# Altium schematic sheet AUDIO.SchDoc(AUDIO)
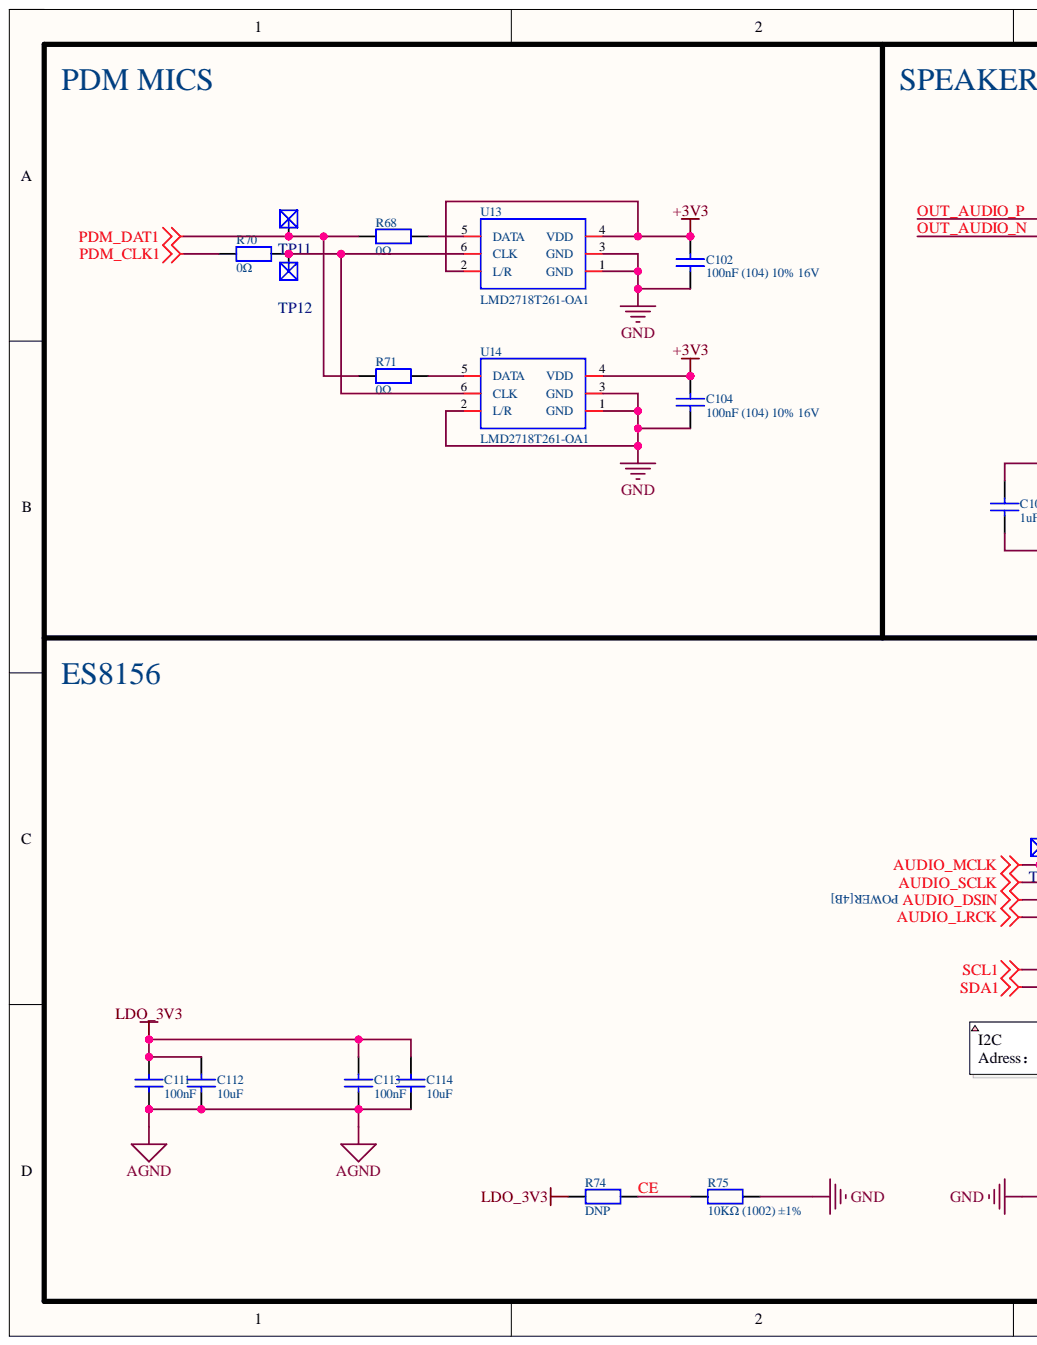
[diagram p0: AUDIO.SchDoc, left]
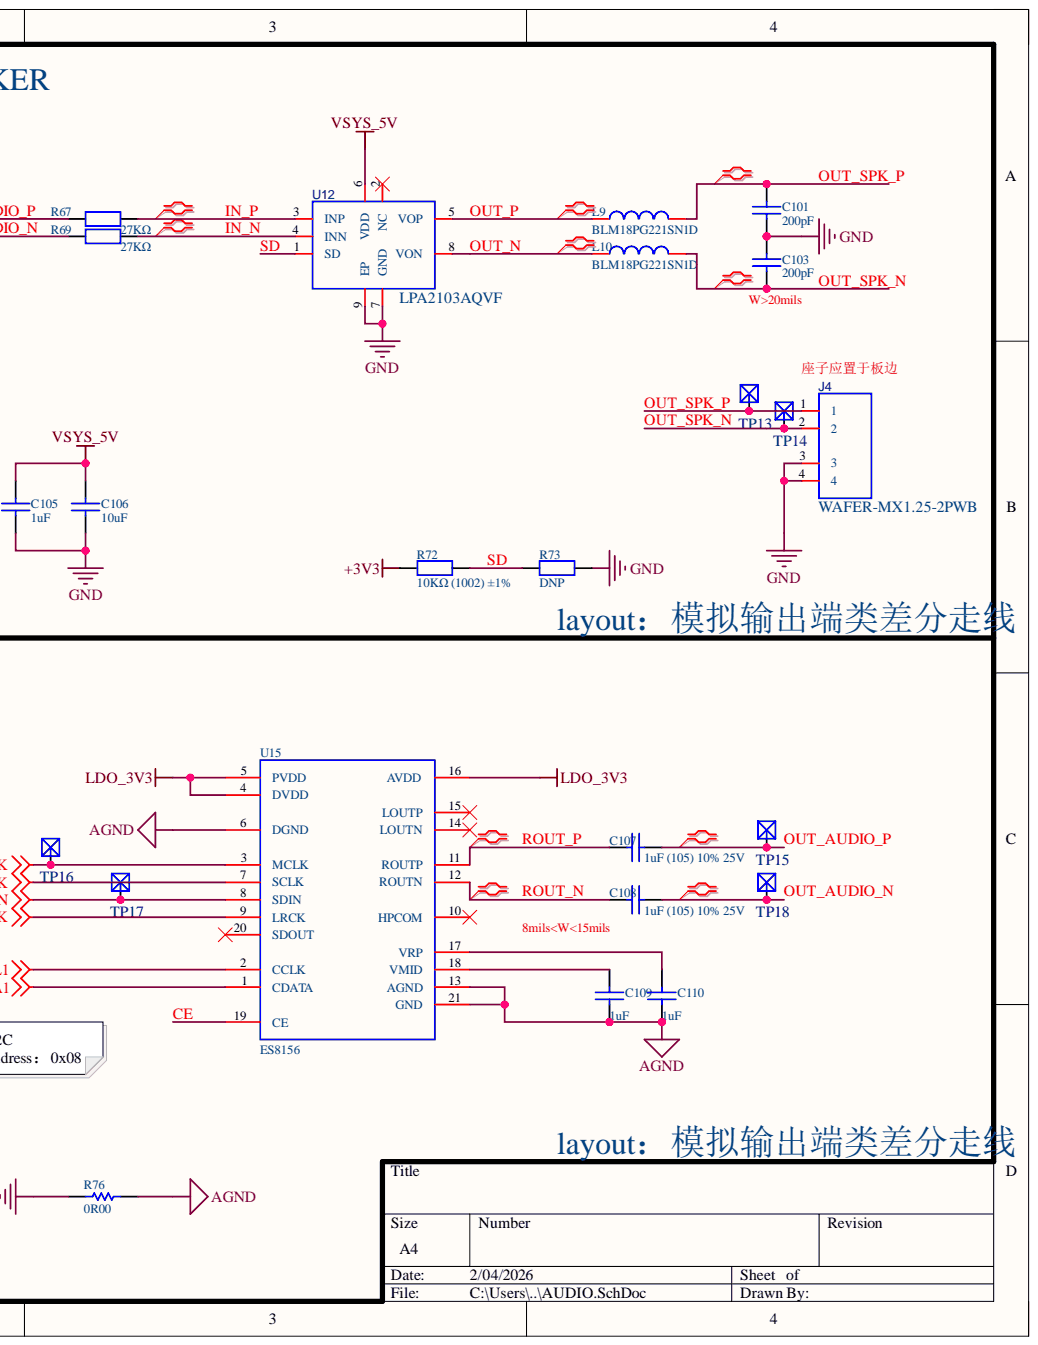
[diagram p1: AUDIO.SchDoc, right]

## Parts
C101  200pF  [C0402]
C102  100nF (104) 10% 16V  [C0402]
C103  200pF  [C0402]
C104  100nF (104) 10% 16V  [C0402]
C105  1uF  [C0402]
C106  10uF  [C0603]
C107  1uF (105) 10% 25V  [C0402]
C108  1uF (105) 10% 25V  [C0402]
C109  1uF  [C0402]
C110  1uF  [C0402]
C111  100nF  [C0402]
C112  10uF  [C0603]
C113  100nF  [C0402]
C114  10uF  [C0603]
J4  WAFER-MX1.25-2PWB  [CONN-SMD_2P-P1.25_XUNPU_WAFER-MX1.25-2PWB]
L9  BLM18PG221SN1D  [L0603]
L10  BLM18PG221SN1D  [L0603]
R67  27KΩ  [R0402]
R68  0Ω  [R0402]
R69  27KΩ  [R0402]
R70  0Ω  [R0402]
R71  0Ω  [R0402]
R72  10KΩ (1002) ±1%  [R0402]
R73  DNP  [R0402]
R74  DNP  [R0402]
R75  10KΩ (1002) ±1%  [R0402]
R76  Resistance: 0R00  [R0805]  (hidden comment: 0805 0R)
TP11  TEST POINT  [TP_M_0.5]  (value not drawn)
TP12  TEST POINT  [TP_M_0.5]  (value not drawn)
TP13  TEST POINT  [TP_M_0.5]  (value not drawn)
TP14  TEST POINT  [TP_M_0.5]  (value not drawn)
TP15  TEST POINT  [TP_M_0.5]  (value not drawn)
TP16  TEST POINT  [TP_M_0.5]  (value not drawn)
TP17  TEST POINT  [TP_M_0.5]  (value not drawn)
TP18  TEST POINT  [TP_M_0.5]  (value not drawn)
U12  LPA2103AQVF  [DFN-8_L2.0-W2.0-P0.50-BL-EP1.5]
U13  LMD2718T261-OA1  [MIC-SMD_6P-L2.8-W1.9-P0.98-TL]
U14  LMD2718T261-OA1  [MIC-SMD_6P-L2.8-W1.9-P0.98-TL]
U15  ES8156  [QFN-20_L3.0-W3.0-P0.40-TL-EP1.7]

## Nets
+3V3: C102.2 C104.2 R72.2 U13.2(L/R) U13.4(VDD) U14.4(VDD)
AGND: C109.1 C110.1 C111.1 C112.1 C113.1 C114.1 R76.2 U15.6(DGND) U15.13(AGND) U15.21(GND)
AUDIO_DSIN: TP17.1 U15.8(SDIN)
AUDIO_LRCK: U15.9(LRCK)
AUDIO_MCLK: TP16.1 U15.3(MCLK)
AUDIO_SCLK: U15.7(SCLK)
GND: C101.1 C102.1 C103.2 C104.1 C105.2 C106.1 J4.3 J4.4 R73.1 R75.1 R76.1 U12.7(GND) U12.9(EP) U13.1(GND) U13.3(GND) U14.1(GND) U14.2(L/R) U14.3(GND)
LDO_3V3: C111.2 C112.2 C113.2 C114.2 R74.2 U15.4(DVDD) U15.5(PVDD) U15.16(AVDD)
PDM_CLK1: R70.1
PDM_DAT1: R68.1 R71.1 TP11.1
SCL1: U15.2(CCLK)
SDA1: U15.1(CDATA)
VSYS_5V: C105.1 C106.2 U12.6(VDD)
CE: R74.1 R75.2 U15.19(CE)
IN_N: R69.2 U12.4(INN)
IN_P: R67.2 U12.3(INP)
OUT_AUDIO_N: C108.1 R69.1 TP18.1
OUT_AUDIO_P: C107.1 R67.1 TP15.1
OUT_N: L10.1 U12.8(VON)
OUT_P: L9.1 U12.5(VOP)
OUT_SPK_N: C103.1 J4.2 L10.2 TP14.1
OUT_SPK_P: C101.2 J4.1 L9.2 TP13.1
ROUT_N: C108.2 U15.12(ROUTN)
ROUT_P: C107.2 U15.11(ROUTP)
SD: R72.1 R73.2 U12.1(SD)
NetC109_2: C109.2 U15.18(VMID)
NetC110_2: C110.2 U15.17(VRP)
NetR68_2: R68.2 U13.5(DATA)
NetR70_2: R70.2 TP12.1 U13.6(CLK) U14.6(CLK)
NetR71_2: R71.2 U14.5(DATA)

Not connected: U12 2(NC); U15 10(HPCOM) 14(LOUTN) 15(LOUTP) 20(SDOUT)

## Library data of the parts
C101 C103 = 0402 200pF: 贴片电容，GCM1555C1H201FA16D; Component Kind: Standard; ComponentLink1Description: 供应商链接; ComponentLink1URL: https://item.szlcsc.com/3515489.html?fromZone=s_s__%2522200pF%2522&spm=sc.gbn.xh2.zy.n___sc.it.hd.ss&lcsc_vid=RFBdUgFWTgRXA1VWFlVXVlZfEVRbAgVXRAcPX1ZSE1YxVlNQQVZdUVVRRlhYVTsOAxUeFF5JWBIBSRccGwIdBEoFGAxBAAgJFQACSQwSGg0%3D; Library Name: RA8P1.SCHLIB; Package: 0402; Supplier: LC; SuppliersPartNumber: C3011672
C102 C104 = 0402 100nF (104) 10% 16V: 贴片电容; Component Kind: Standard; ComponentLink1Description: 供应商链接; ComponentLink1URL: https://item.szlcsc.com/42839.html; Library Name: RA8P1.SCHLIB; Package: 0402; Supplier: LC; SuppliersPartNumber: C1525
C105 C107-C110 = 0402 1uF (105) 10% 25V: 贴片电容; Component Kind: Standard; ComponentLink1Description: 供应商链接; ComponentLink1URL: http://www.szlcsc.com/product/details_53938.html; Library Name: RA8P1.SCHLIB; Package: 0402; Supplier: LC; SuppliersPartNumber: C52923
C106 C112 C114 = 0603 10uF (106) 10% 10V: 贴片电容; Component Kind: Standard; ComponentLink1Description: 供应商链接; ComponentLink1URL: http://www.szlcsc.com/product/details_20411.html; Library Name: RA8P1.SCHLIB; Package: 0603; Supplier: LC; SuppliersPartNumber: C19702
C111 C113 = 0402 100nF (104) 10% 50V: GRM155R71H104KE14D,100nF (104) 10% 50V; Component Kind: Standard; ComponentLink1Description: 供应商链接; ComponentLink1URL: https://item.szlcsc.com/78147.html?fromZone=l_c__%2522catalog%2522&spm=sc.cid.xh1.zy.n___sc.gb.xh1.zy.l&lcsc_vid=FgdZAQZURgVfVlRQQQNbBAcFQVZYX1NeQ1BYX10ARFkxVlNSQ1FZUVReQVdaUjsOAxUeFF5JWAgaAglIBBsCBBcFWQIBCks%3D; Library Name: RA8P1.SCHLIB; Package: 0603; Supplier: LC; SuppliersPartNumber: C1525
L9 L10 = BLM18PG221SN1D: 磁珠，0603，1.4A; Manufacturer: muRata(村田); Add into BOM: yes; Circuits: 1; Current Rating: 1.4A; DC Resistance: 100mΩ; Impedance @ Frequency: 220Ω@100MHz; Tolerance: ±25%; Component Kind: Standard; Convert to PCB: yes; Datasheet: https://atta.szlcsc.com/upload/public/pdf/source/20191226/C476007_90F4628D6A1A8703036BE981B9833918.pdf; Device: BLM18PG221SN1D; JLCPCB Part Class: Extended Part; LCSC Part Name: 单路 0603磁珠 阻抗220Ω@100MHz; Library Name: ra8p1_v0.1.SCHLIB; Manufacturer Part: BLM18PG221SN1D; PCB3D: L0603_L1.6-W0.8-H0.5_BEAD; Supplier: LCSC; Supplier Footprint: 0603; Supplier Part: C80165; Symbol: BLM18PG221SN1D
R67 R69 = 0402 27KΩ (2702) 1%: 贴片电阻; Component Kind: Standard; ComponentLink1Description: 供应商链接; ComponentLink1URL: http://www.szlcsc.com/product/details_26514.html; Library Name: RA8P1.SCHLIB; Package: 0402; Supplier: LC; SuppliersPartNumber: C25771
R68 R70 R71 = 0402 0Ω (0R0) 1%: 贴片电阻; Component Kind: Standard; ComponentLink1Description: 供应商链接; ComponentLink1URL: http://www.szlcsc.com/product/details_17853.html; Library Name: RA8P1.SCHLIB; Package: 0402; Supplier: LC; SuppliersPartNumber: C17168
R72-R75 = 0402 10KΩ (1002) 1%: 贴片电阻，10K; Component Kind: Standard; ComponentLink1Description: 供应商链接; ComponentLink1URL: https://item.szlcsc.com/26487.html?fromZone=l_b__%2522brand%2522&spm=sc.bid.xh1.zy.n&lcsc_vid=FANcVFBQFQMNX1cFFAdZVVZXEgdeAgdWFlQNUVAHT1ExVlNSRFhXVlJQQFlYVzsOAxUeFF5JWA0HFBBIBBsCBBcFWQIBCksEBQAAA0tfTk8GEwkK; Library Name: RA8P1.SCHLIB; Package: 0402; Supplier: LC; SuppliersPartNumber: C25744
R76 = 0805 0R: Chip Resistor Thick Film 0805  0R00 1% 125mW，0805W8F0000T5E; Legacy Part Number: 150-00000004; Manufacturer: Yageo; Manufacturer Part Number 1: RC1206JR-070RL; Notes: ANY EQUIVALENT; Power: 1/4W; ClassName: MCU; Component Kind: Standard; Library Name: RA8P1.SCHLIB; Manufacturer 1: Yageo; Mfg: Yageo; Mfg Part Number: RC1206JR-070RL; Package: 1206; PN: RC1206JR-070RL; Supplier 1: Digi-Key; Supplier 2: Future Electronics; Supplier 3: Arrow Electronics; Supplier 4: Avnet; Supplier 5: Digi-Key; Supplier 6: Mouser; Supplier Part Number 1: P0.0ECT-ND; Supplier Part Number 2: 1414689; Supplier Part Number 3: RC1206JR-070RL; Supplier Part Number 4: RC1206JR-070RL; Supplier Part Number 5: 311-0.0ERCT-ND; Supplier Part Number 6: 603-RC1206JR-070RL; Tolerance: 1%
TP11-TP18 = TP: Component Kind: Standard; Library Name: RA8P1.SCHLIB
J4 = WAFER-MX1.25-2PWB: Component Kind: Standard; Library Name: RA8P1.SCHLIB; Symbol: WAFER-MX1.25-2PWB
U12 = LPA2103AQVF: Component Kind: Standard; Library Name: RA8P1.SCHLIB; Symbol: LPA2103AQVF
U13 U14 = LMD2718T261-OA1: Component Kind: Standard; Library Name: RA8P1.SCHLIB; Symbol: LMD2718T261-OA1
U15 = ES8156: Component Kind: Standard; Description: ES8156; Library Name: RA8P1.SCHLIB; Symbol: ES8156
